# Altium schematic sheet Sheet1.SchDoc
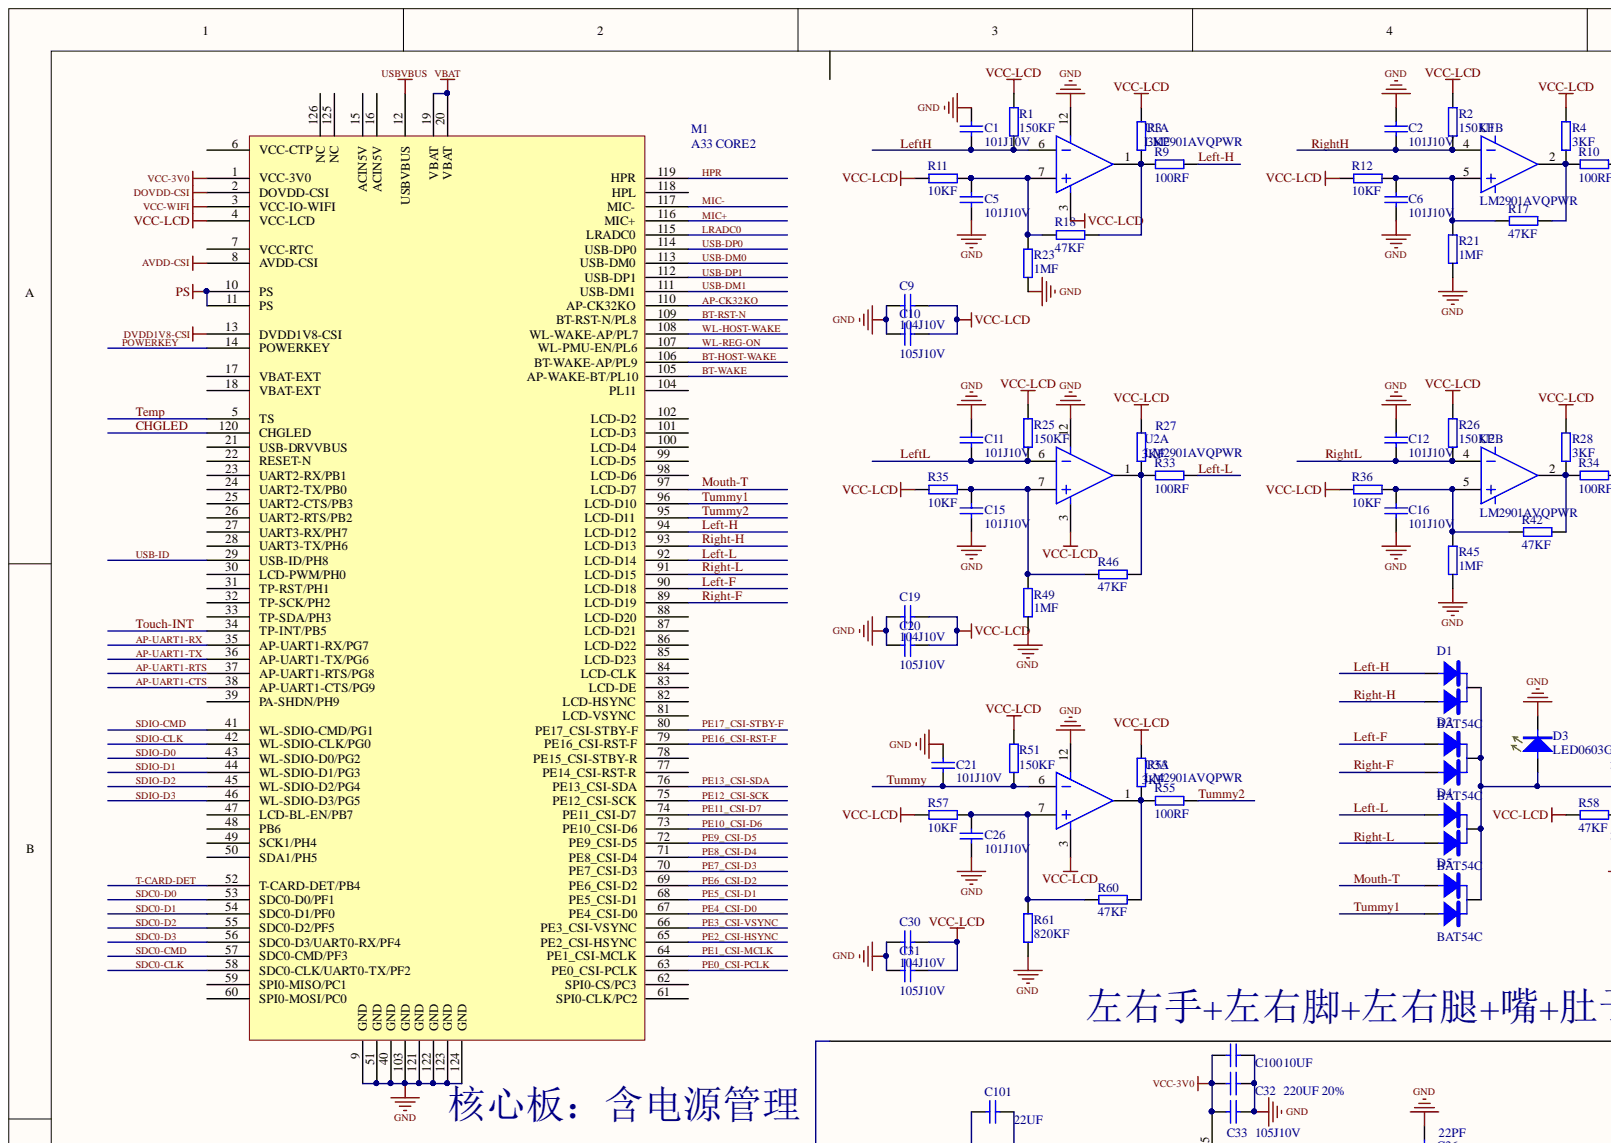
[diagram p0: Sheet1.SchDoc, top left]
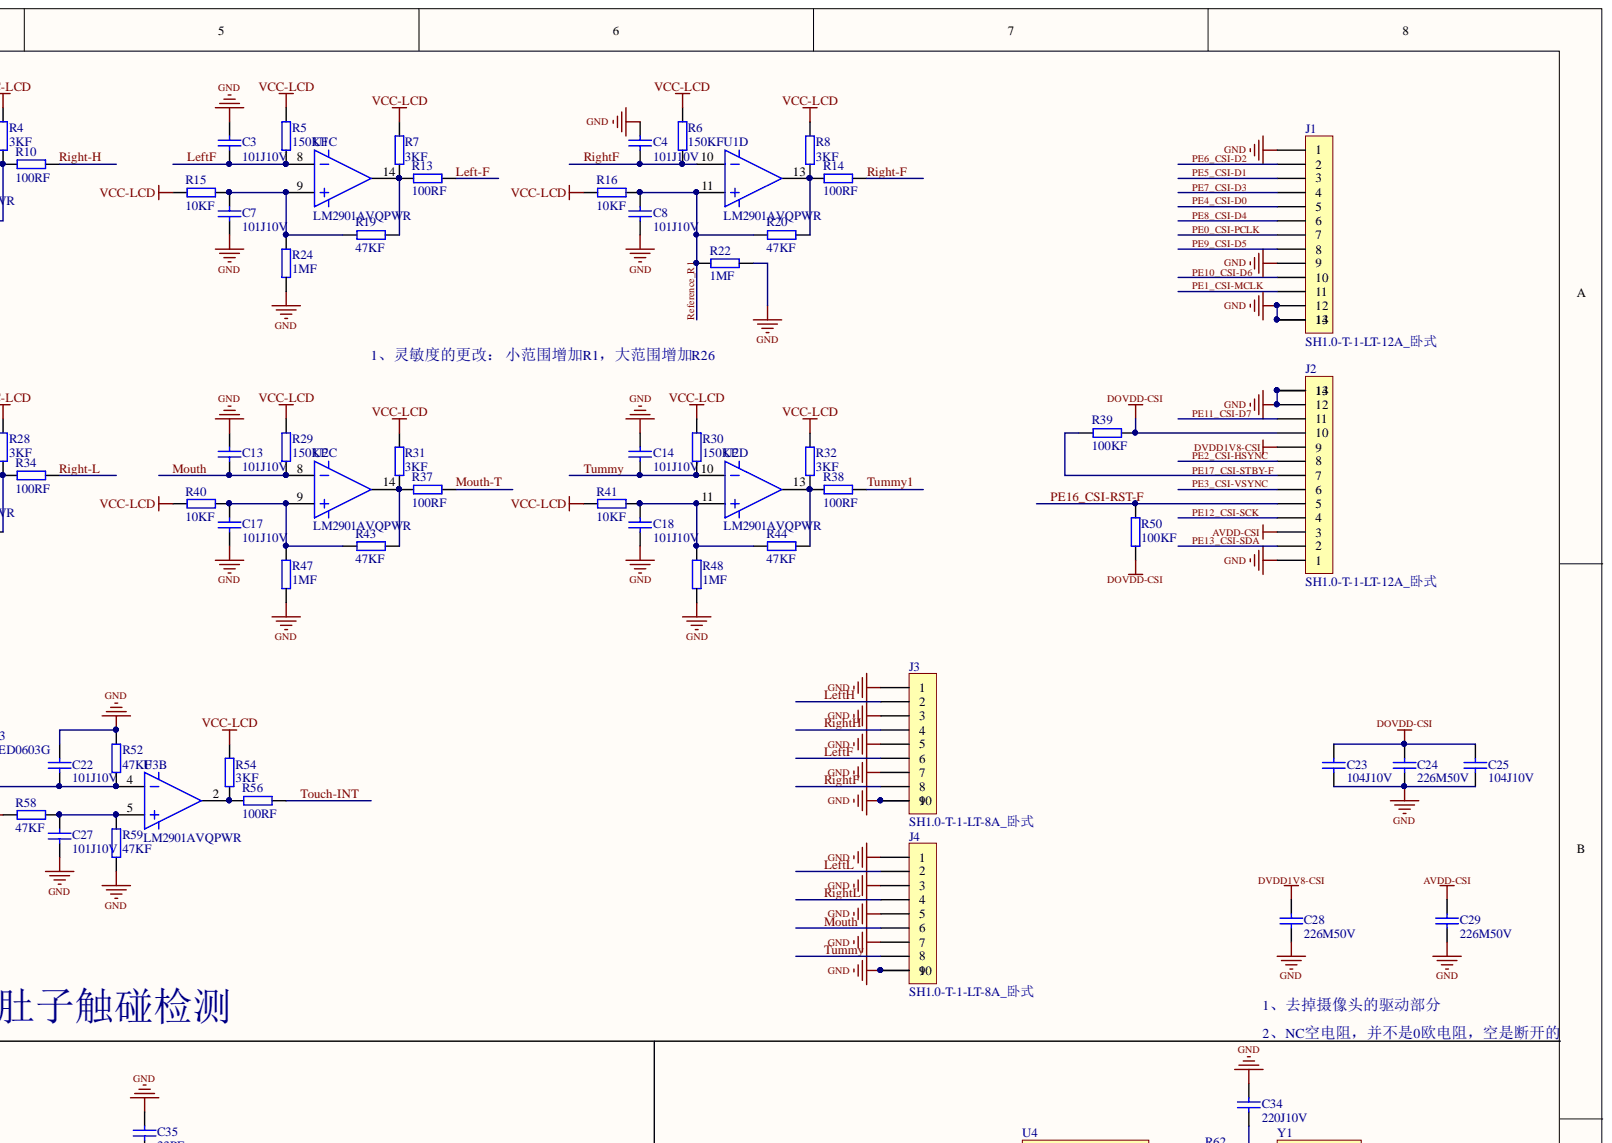
[diagram p1: Sheet1.SchDoc, top right]
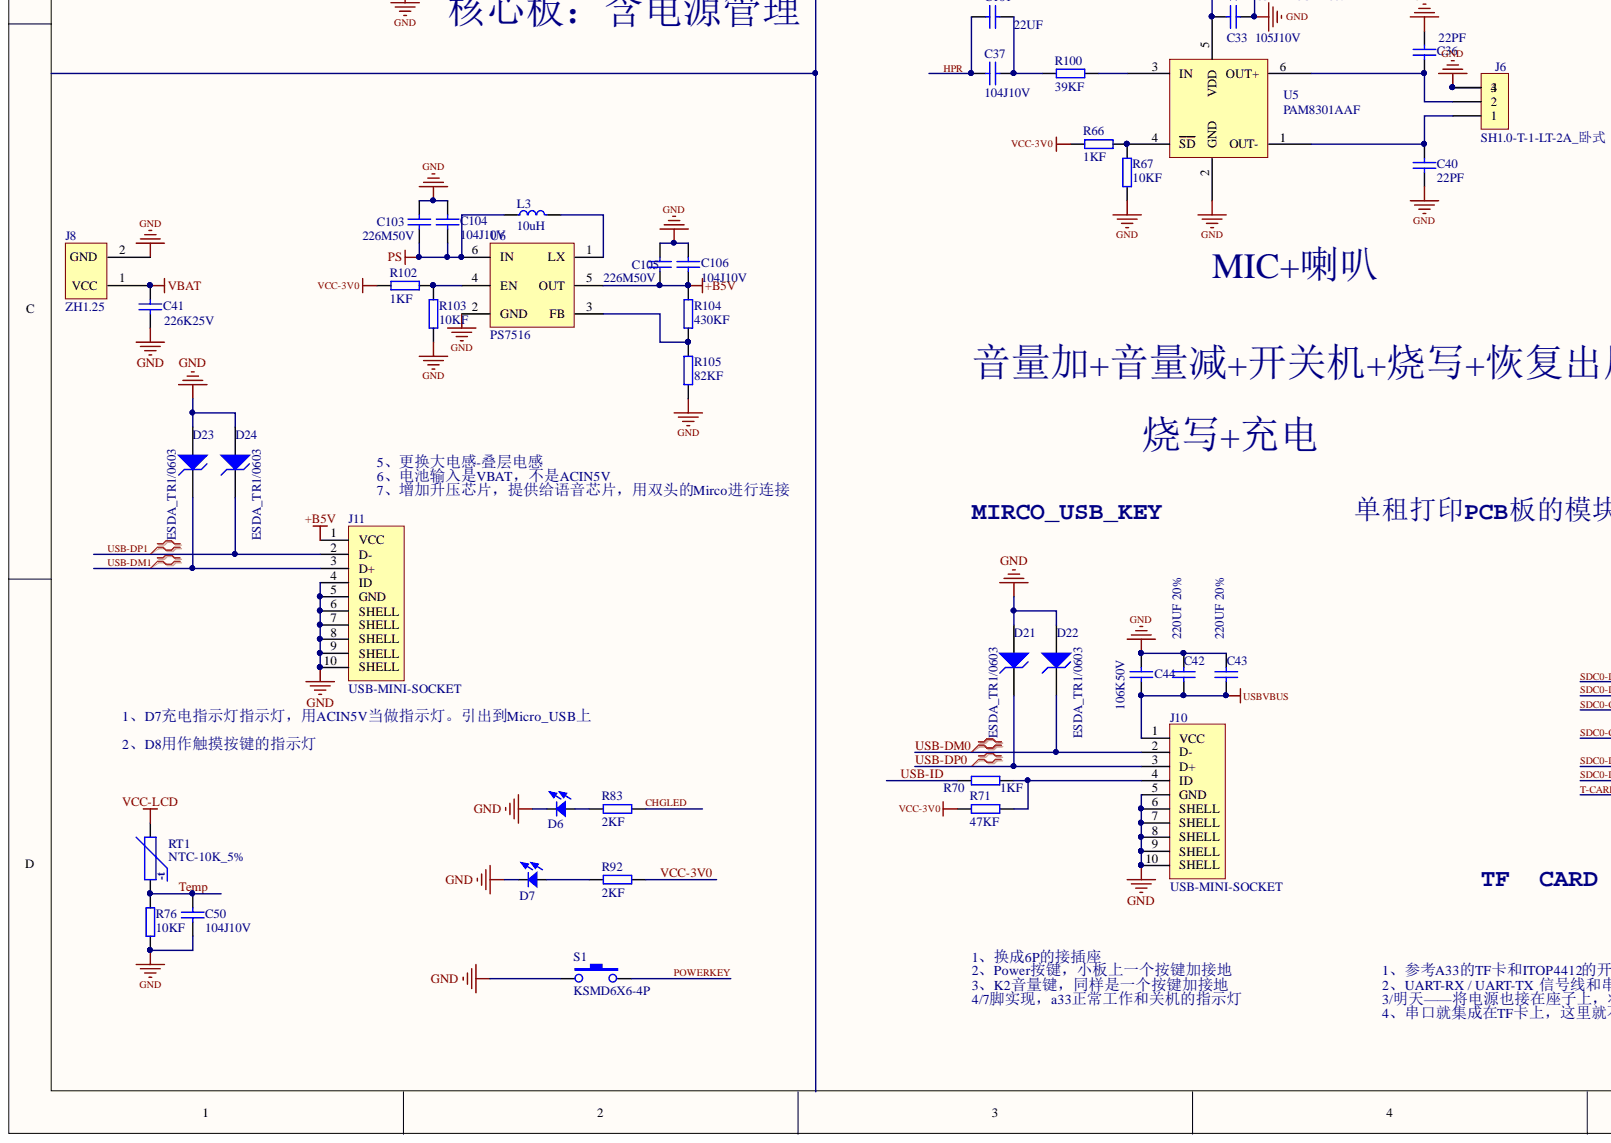
[diagram p2: Sheet1.SchDoc, bottom left]
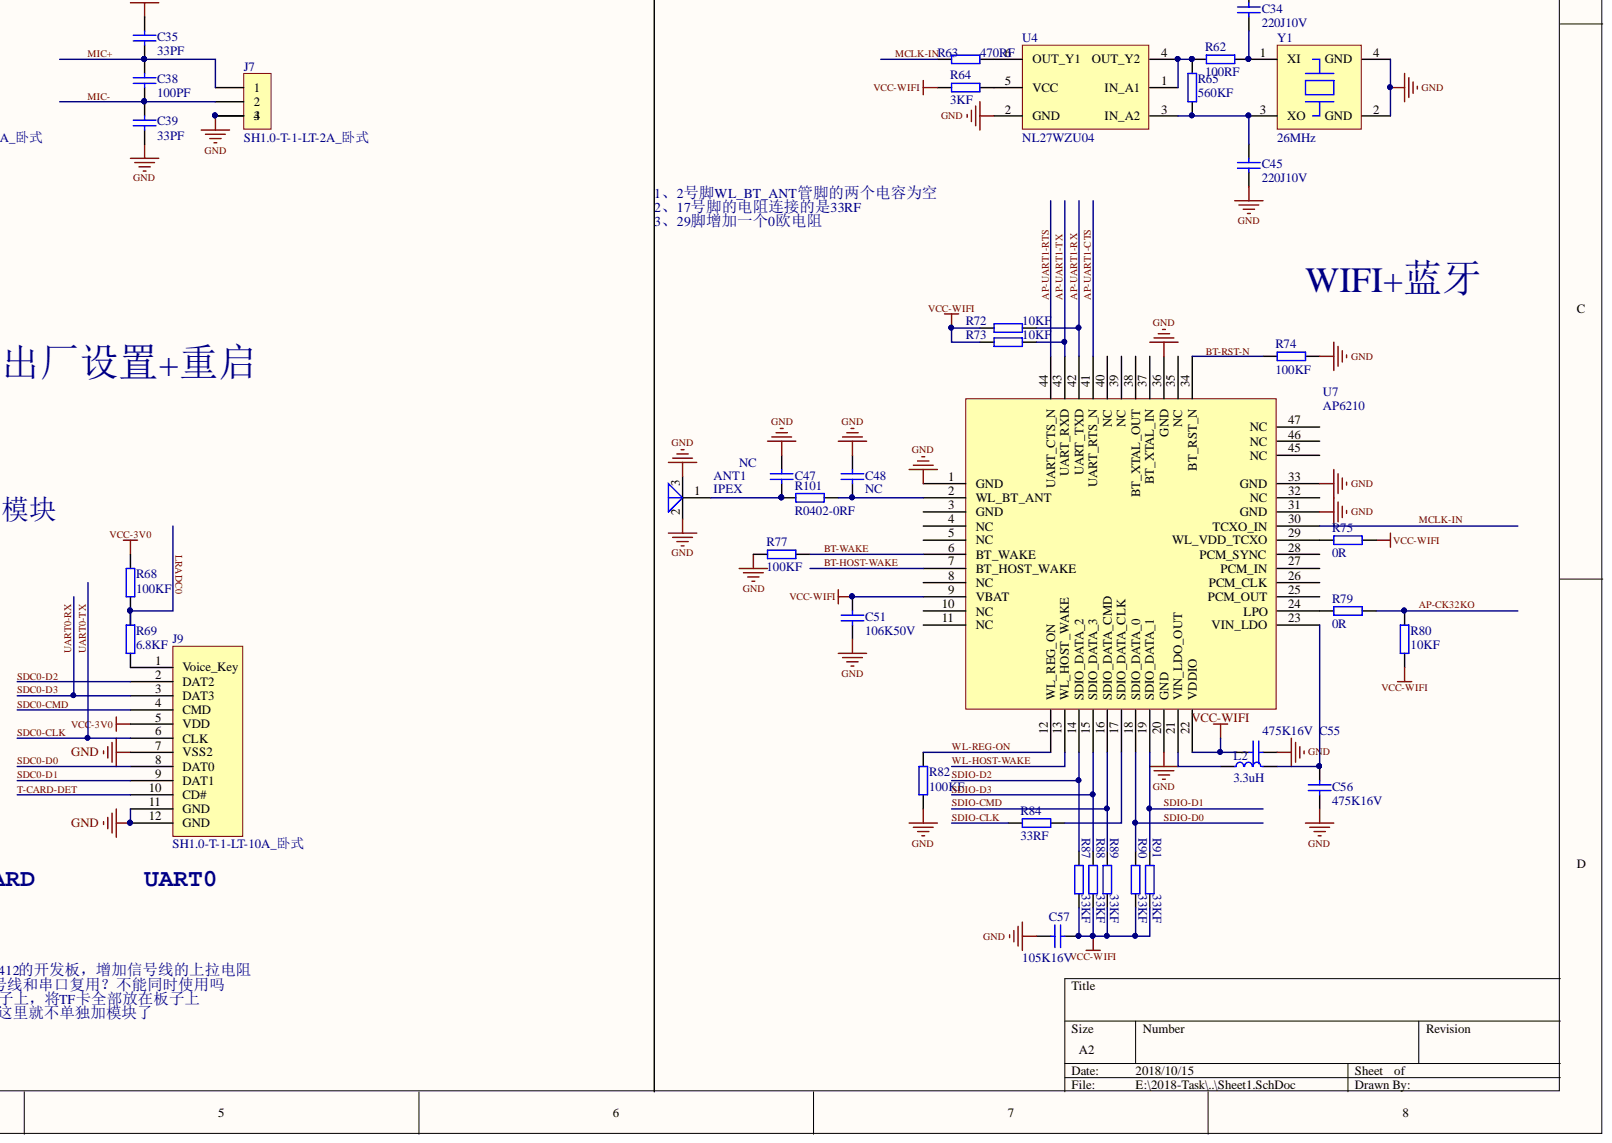
[diagram p3: Sheet1.SchDoc, bottom right]

## Parts
ANT1  IPEX  [J-IPEX]
C1  101J10V  [C0402]
C2  101J10V  [C0402]
C3  101J10V  [C0402]
C4  101J10V  [C0402]
C5  101J10V  [C0402]
C6  101J10V  [C0402]
C7  101J10V  [C0402]
C8  101J10V  [C0402]
C9  104J10V  [C0402]
C10  105J10V  [C0402]
C11  101J10V  [C0402]
C12  101J10V  [C0402]
C13  101J10V  [C0402]
C14  101J10V  [C0402]
C15  101J10V  [C0402]
C16  101J10V  [C0402]
C17  101J10V  [C0402]
C18  101J10V  [C0402]
C19  104J10V  [C0402]
C20  105J10V  [C0402]
C21  101J10V  [C0402]
C22  101J10V  [C0402]
C23  104J10V  [C0402]
C24  226M50V  [C0805]
C25  104J10V  [C0402]
C26  101J10V  [C0402]
C27  101J10V  [C0402]
C28  226M50V  [C0805]
C29  226M50V  [C0805]
C30  104J10V  [C0402]
C31  105J10V  [C0402]
C32  220UF 20%  [C1206]
C33  105J10V  [C0402]
C34  220J10V  [C0402]
C35  33PF  [C0402]
C36  22PF  [C0402]
C37  104J10V  [C0402]
C38  100PF  [C0402]
C39  33PF  [C0402]
C40  22PF  [C0402]
C41  226K25V  [C0805]
C42  220UF 20%  [C1206]
C43  220UF 20%  [C1206]
C44  106K50V  [C0603]
C45  220J10V  [C0402]
C47  NC  [C0402]
C48  NC  [C0402]
C50  104J10V  [C0402]
C51  106K50V  [C0603]
C55  475K16V  [C0603]
C56  475K16V  [C0603]
C57  105K16V  [C0603]
C100  10UF  [C0603]
C101  22UF  [C0805]
C103  226M50V  [C0805]
C104  104J10V  [C0402]
C105  226M50V  [C0805]
C106  104J10V  [C0402]
D1  BAT54C  [U-SOT-23-3]
D2  BAT54C  [U-SOT-23-3]
D3  LED0603G  [D-LED0603G]
D4  BAT54C  [U-SOT-23-3]
D5  BAT54C  [U-SOT-23-3]
D6  发光二极管  [LEDΦ5-H12]  (value not drawn)
D7  发光二极管  [LEDΦ5-H12]  (value not drawn)
D21  ESDA_TR1/0603  [HS_0603D]
D22  ESDA_TR1/0603  [HS_0603D]
D23  ESDA_TR1/0603  [HS_0603D]
D24  ESDA_TR1/0603  [HS_0603D]
J1  SH1.0-T-1-LT-12A_卧式  [J-SH1.0-T-1-LT-12A_卧式]
J2  SH1.0-T-1-LT-12A_卧式  [J-SH1.0-T-1-LT-12A_卧式]
J3  SH1.0-T-1-LT-8A_卧式  [J-SH1.0-T-1-LT-8A_卧式]
J4  SH1.0-T-1-LT-8A_卧式  [J-SH1.0-T-1-LT-8A_卧式]
J6  SH1.0-T-1-LT-2A_卧式  [J-SH1.0-T-1-LT-2A_卧式]
J7  SH1.0-T-1-LT-2A_卧式  [J-SH1.0-T-1-LT-2A_卧式]
J8  ZH1.25  [S1.25-2P]
J9  SH1.0-T-1-LT-10A_卧式  [J-SH1.0-T-1-LT-10A_卧式]
J10  USB-MINI-SOCKET  [MICRO-USB - 5.9]
J11  USB-MINI-SOCKET  [MICRO-USB - 5.9]
L2  3.3uH  [L0805]
L3  10uH  [L0805]
M1  A33 CORE2  [A33-CORE2]
R1  150KF  [R0603]
R2  150KF  [R0603]
R3  3KF  [R0603]
R4  3KF  [R0603]
R5  150KF  [R0603]
R6  150KF  [R0603]
R7  3KF  [R0603]
R8  3KF  [R0603]
R9  100RF  [R0603]
R10  100RF  [R0603]
R11  10KF  [R0603]
R12  10KF  [R0603]
R13  100RF  [R0603]
R14  100RF  [R0603]
R15  10KF  [R0603]
R16  10KF  [R0603]
R17  47KF  [R0603]
R18  47KF  [R0603]
R19  47KF  [R0603]
R20  47KF  [R0603]
R21  1MF  [R0603]
R22  1MF  [R0603]
R23  1MF  [R0603]
R24  1MF  [R0603]
R25  150KF  [R0603]
R26  150KF  [R0603]
R27  3KF  [R0603]
R28  3KF  [R0603]
R29  150KF  [R0603]
R30  150KF  [R0603]
R31  3KF  [R0603]
R32  3KF  [R0603]
R33  100RF  [R0603]
R34  100RF  [R0603]
R35  10KF  [R0603]
R36  10KF  [R0603]
R37  100RF  [R0603]
R38  100RF  [R0603]
R39  100KF  [R0603]
R40  10KF  [R0603]
R41  10KF  [R0603]
R42  47KF  [R0603]
R43  47KF  [R0603]
R44  47KF  [R0603]
R45  1MF  [R0603]
R46  47KF  [R0603]
R47  1MF  [R0603]
R48  1MF  [R0603]
R49  1MF  [R0603]
R50  100KF  [R0603]
R51  150KF  [R0603]
R52  47KF  [R0603]
R53  3KF  [R0603]
R54  3KF  [R0603]
R55  100RF  [R0603]
R56  100RF  [R0603]
R57  10KF  [R0603]
R58  47KF  [R0603]
R59  47KF  [R0603]
R60  47KF  [R0603]
R61  820KF  [R0603]
R62  100RF  [R0603]
R63  470RF  [R0603]
R64  3KF  [R0603]
R65  560KF  [R0603]
R66  1KF  [R0603]
R67  10KF  [R0603]
R68  100KF  [R0603]
R69  6.8KF  [R0603]
R70  1KF  [R0603]
R71  47KF  [R0603]
R72  10KF  [R0603]
R73  10KF  [R0603]
R74  100KF  [R0603]
R75  0R  [R0603]
R76  10KF  [R0603]
R77  100KF  [R0603]
R79  0R  [R0603]
R80  10KF  [R0603]
R82  100KF  [R0603]
R83  2KF  [R0603]
R84  33RF  [R0603]
R87  33KF  [R0603]
R88  33KF  [R0603]
R89  33KF  [R0603]
R90  33KF  [R0603]
R91  33KF  [R0603]
R92  2KF  [R0603]
R100  39KF  [R0603]
R101  R0402-0RF  [C0402]
R102  1KF  [R0603]
R103  10KF  [R0603]
R104  430KF  [R0603]
R105  82KF  [R0603]
RT1  NTC-10K_5%  [R-NTC-10K]
S1  KSMD6X6-4P  [SW2-6*6H]
U1  LM2901AVQPWR  [U-TSSOP14]  (parts A,B,C,D)
U2  LM2901AVQPWR  [U-TSSOP14]  (parts A,B,C,D)
U3  LM2901AVQPWR  [U-TSSOP14]  (parts A,B)
U4  NL27WZU04  [U-TSOP-6]  (value not drawn)
U5  PAM8301AAF  [U-TSOT26]
U6  PS7516  [U-SOT-23-6]
U7  AP6210  [AP6210]
Y1  26MHz  [Y-CPX-32GA-4P]

## Nets
+B5V: C105.1 C106.2 J11.1(VCC) R104.2 U6.5(OUT)
AVDD-CSI: C29.1 J2.3 M1.8(AVDD-CSI)
DOVDD-CSI: C23.1 C24.1 C25.1 J2.10 M1.2(DOVDD-CSI) R39.2 R50.1
DVDD1V8-CSI: C28.1 J2.9 M1.13(DVDD1V8-CSI)
GND: ANT1.2 ANT1.3 C1.1 C2.1 C3.1 C4.1 C5.2 C6.2 C7.2 C8.2 C9.1 C10.2 C11.1 C12.1 C13.1 C14.1 C15.2 C16.2 C17.2 C18.2 C19.1 C20.2 C21.1 C22.1 C23.2 C24.2 C25.2 C26.2 C27.2 C28.2 C29.2 C30.1 C31.2 C32.1 C33.1 C34.2 C35.1 C36.2 C39.2 C40.2 C41.2 C42.2 C43.2 C44.1 C45.2 C47.1 C48.1 C50.2 C51.2 C55.1 C56.1 C57.2 C100.2 C103.2 C104.1 C105.2 C106.1 D3.2 D6.K D7.K D21.1 D22.1 D23.1 D24.1 J1.1 J1.9 J1.12 J1.13 J1.14 J2.1 J2.12 J2.13 J2.14 J3.1 J3.3 J3.5 J3.7 J3.9 J3.10 J4.1 J4.3 J4.5 J4.7 J4.9 J4.10 J6.3 J6.4 J7.3 J7.4 J8.2 J9.7(VSS2) J9.11(GND) J9.12(GND) J10.5(GND) J10.6(SHELL) J10.7(SHELL) J10.8(SHELL) J10.9(SHELL) J10.10(SHELL) J11.4(ID) J11.5(GND) J11.6(SHELL) J11.7(SHELL) J11.8(SHELL) J11.9(SHELL) J11.10(SHELL) M1.9(GND) M1.40(GND) M1.51(GND) M1.103(GND) M1.121(GND) M1.122(GND) M1.123(GND) M1.124(GND) R21.1 R22.1 R23.1 R24.1 R45.1 R47.1 R48.1 R49.1 R52.1 R59.1 R61.1 R67.1 R74.2 R76.1 R77.2 R82.2 R103.1 R105.1 S1.1 U1.12 U2.12 U3.12 U4.2(GND) U5.2(GND) U6.2(GND) U7.1(GND) U7.20(GND) U7.31(GND) U7.33(GND) U7.36(GND) Y1.2(GND) Y1.4(GND)
PS: C103.1 C104.2 L3.1 M1.10(PS) M1.11(PS) U6.6(IN)
USBVBUS: C42.1 C43.1 C44.2 J10.1(VCC) M1.12(USBVBUS)
VBAT: C41.1 J8.1 M1.19(VBAT) M1.20(VBAT)
VCC-LCD: C9.2 C10.1 C19.2 C20.1 C30.2 C31.1 M1.4(VCC-LCD) R1.2 R2.2 R3.2 R4.2 R5.2 R6.2 R7.2 R8.2 R11.1 R12.1 R15.1 R16.1 R25.2 R26.2 R27.2 R28.2 R29.2 R30.2 R31.2 R32.2 R35.1 R36.1 R40.1 R41.1 R51.2 R53.2 R54.2 R57.1 R58.2 RT1.2 U1.3 U2.3 U3.3
VCC-WIFI: C51.1 C55.2 C57.1 M1.3(VCC-IO-WIFI) R64.1 R72.1 R73.1 R75.2 R80.1 R87.1 R88.1 R89.1 R90.1 R91.1 U7.9(VBAT) U7.22(VDDIO)
AP-CK32KO: M1.110(AP-CK32KO) R79.2 R80.2
AP-UART1-CTS: M1.38(AP-UART1-CTS/PG9) U7.41(UART_RTS_N)
AP-UART1-RTS: M1.37(AP-UART1-RTS/PG8) U7.44(UART_CTS_N)
AP-UART1-RX: M1.35(AP-UART1-RX/PG7) R72.2 U7.42(UART_TXD)
AP-UART1-TX: M1.36(AP-UART1-TX/PG6) R73.2 U7.43(UART_RXD)
BT-HOST-WAKE: M1.106(BT-WAKE-AP/PL9) U7.7(BT_HOST_WAKE)
BT-RST-N: M1.109(BT-RST-N/PL8) R74.1 U7.34(BT_RST_N)
BT-WAKE: M1.105(AP-WAKE-BT/PL10) R77.1 U7.6(BT_WAKE)
CHGLED: M1.120(CHGLED) R83.2
HPR: C37.2 C101.1 M1.119(HPR)
LEFT-F: D2.1 M1.90(LCD-D18) R13.2
LEFT-H: D1.2 M1.94(LCD-D12) R9.2
LEFT-L: D4.2 M1.92(LCD-D14) R33.2
LEFTF: C3.2 J3.6 R5.1 U1.8
LEFTH: C1.2 J3.2 R1.1 U1.6
LEFTL: C11.2 J4.2 R25.1 U2.6
LRADC0: M1.115(LRADC0) R68.2 R69.1
MCLK-IN: R63.1 U7.30(TCXO_IN)
MIC+: C35.2 C38.1 J7.1 M1.116(MIC+)
MIC-: C38.2 C39.1 J7.2 M1.117(MIC-)
MOUTH: C13.2 J4.6 R29.1 U2.8
MOUTH-T: D5.1 M1.97(LCD-D7) R37.2
PE0_CSI-PCLK: J1.7 M1.63(PE0_CSI-PCLK)
PE1_CSI-MCLK: J1.11 M1.64(PE1_CSI-MCLK)
PE2_CSI-HSYNC: J2.8 M1.65(PE2_CSI-HSYNC)
PE3_CSI-VSYNC: J2.6 M1.66(PE3_CSI-VSYNC)
PE4_CSI-D0: J1.5 M1.67(PE4_CSI-D0)
PE5_CSI-D1: J1.3 M1.68(PE5_CSI-D1)
PE6_CSI-D2: J1.2 M1.69(PE6_CSI-D2)
PE7_CSI-D3: J1.4 M1.70(PE7_CSI-D3)
PE8_CSI-D4: J1.6 M1.71(PE8_CSI-D4)
PE9_CSI-D5: J1.8 M1.72(PE9_CSI-D5)
PE10_CSI-D6: J1.10 M1.73(PE10_CSI-D6)
PE11_CSI-D7: J2.11 M1.74(PE11_CSI-D7)
PE12_CSI-SCK: J2.4 M1.75(PE12_CSI-SCK)
PE13_CSI-SDA: J2.2 M1.76(PE13_CSI-SDA)
PE16_CSI-RST-F: J2.5 M1.79(PE16_CSI-RST-F) R50.2
PE17_CSI-STBY-F: J2.7 M1.80(PE17_CSI-STBY-F) R39.1
POWERKEY: M1.14(POWERKEY) S1.2
REFERENCE_R1: C8.1 R16.2 R20.2 R22.2 U1.11
RIGHT-F: D2.2 M1.89(LCD-D19) R14.2
RIGHT-H: D1.1 M1.93(LCD-D13) R10.2
RIGHT-L: D4.1 M1.91(LCD-D15) R34.2
RIGHTF: C4.2 J3.8 R6.1 U1.10
RIGHTH: C2.2 J3.4 R2.1 U1.4
RIGHTL: C12.2 J4.4 R26.1 U2.4
SDC0-CLK: J9.6(CLK) M1.58(SDC0-CLK/UART0-TX/PF2)
SDC0-CMD: J9.4(CMD) M1.57(SDC0-CMD/PF3)
SDC0-D0: J9.8(DAT0) M1.53(SDC0-D0/PF1)
SDC0-D1: J9.9(DAT1) M1.54(SDC0-D1/PF0)
SDC0-D2: J9.2(DAT2) M1.55(SDC0-D2/PF5)
SDC0-D3: J9.3(DAT3) M1.56(SDC0-D3/UART0-RX/PF4)
SDIO-CLK: M1.42(WL-SDIO-CLK/PG0) R84.2
SDIO-CMD: M1.41(WL-SDIO-CMD/PG1) R89.2 U7.16(SDIO_DATA_CMD)
SDIO-D0: M1.43(WL-SDIO-D0/PG2) R90.2 U7.18(SDIO_DATA_0)
SDIO-D1: M1.44(WL-SDIO-D1/PG3) R91.2 U7.19(SDIO_DATA_1)
SDIO-D2: M1.45(WL-SDIO-D2/PG4) R87.2 U7.14(SDIO_DATA_2)
SDIO-D3: M1.46(WL-SDIO-D3/PG5) R88.2 U7.15(SDIO_DATA_3)
T-CARD-DET: J9.10(CD#) M1.52(T-CARD-DET/PB4)
TEMP: C50.1 M1.5(TS) R76.2 RT1.1
TOUCH-INT: M1.34(TP-INT/PB5) R56.2
TUMMY: C14.2 C21.2 J4.8 R30.1 R51.1 U2.10 U3.6
TUMMY1: D5.2 M1.96(LCD-D10) R38.2
TUMMY2: M1.95(LCD-D11) R55.2
USB-DM0: D22.2 J10.2(D-) M1.113(USB-DM0)
USB-DM1: D23.2 J11.3(D+) M1.111(USB-DM1)
USB-DP0: D21.2 J10.3(D+) M1.114(USB-DP0)
USB-DP1: D24.2 J11.2(D-) M1.112(USB-DP1)
USB-ID: M1.29(USB-ID/PH8) R70.1
VCC-3V0: C32.2 C33.2 C100.1 J9.5(VDD) M1.1(VCC-3V0) R66.1 R68.1 R71.2 R92.2 R102.1 U5.5(VDD)
WL-HOST-WAKE: M1.108(WL-WAKE-AP/PL7) U7.13(WL_HOST_WAKE)
WL-REG-ON: M1.107(WL-PMU-EN/PL6) R82.1 U7.12(WL_REG_ON)
NetANT1_1: ANT1.1 C47.2 R101.1
NetC5_1: C5.1 R11.2 R18.2 R23.2 U1.7
NetC6_1: C6.1 R12.2 R17.2 R21.2 U1.5
NetC7_1: C7.1 R15.2 R19.2 R24.2 U1.9
NetC15_1: C15.1 R35.2 R46.2 R49.2 U2.7
NetC16_1: C16.1 R36.2 R42.2 R45.2 U2.5
NetC17_1: C17.1 R40.2 R43.2 R47.2 U2.9
NetC18_1: C18.1 R41.2 R44.2 R48.2 U2.11
NetC22_2: C22.2 D1.3 D2.3 D3.1 D4.3 D5.3 R52.2 U3.4
NetC26_1: C26.1 R57.2 R60.1 R61.2 U3.7
NetC27_1: C27.1 R58.1 R59.2 U3.5
NetC34_1: C34.1 R62.2 Y1.1(XI)
NetC36_1: C36.1 J6.2 U5.6(OUT+)
NetC37_1: C37.1 C101.2 R100.1
NetC40_1: C40.1 J6.1 U5.1(OUT-)
NetC45_1: C45.1 R65.1 U4.3(IN_A2) Y1.3(XO)
NetC48_2: C48.2 R101.2 U7.2(WL_BT_ANT)
NetC56_2: C56.2 L2.2 U7.23(VIN_LDO)
NetD6_A: D6.A R83.1
NetD7_A: D7.A R92.1
NetJ9_1: J9.1(Voice_Key) R69.2
NetJ10_4: J10.4(ID) R70.2 R71.1
NetL2_1: L2.1 U7.21(VIN_LDO_OUT)
NetL3_2: L3.2 U6.1(LX)
NetR3_1: R3.1 R9.1 R18.1 U1.1
NetR4_1: R4.1 R10.1 R17.1 U1.2
NetR7_1: R7.1 R13.1 R19.1 U1.14
NetR8_1: R8.1 R14.1 R20.1 U1.13
NetR27_1: R27.1 R33.1 R46.1 U2.1
NetR28_1: R28.1 R34.1 R42.1 U2.2
NetR31_1: R31.1 R37.1 R43.1 U2.14
NetR32_1: R32.1 R38.1 R44.1 U2.13
NetR53_1: R53.1 R55.1 R60.2 U3.1
NetR54_1: R54.1 R56.1 U3.2
NetR62_1: R62.1 R65.2 U4.1(IN_A1) U4.4(OUT_Y2)
NetR63_2: R63.2 U4.6(OUT_Y1)
NetR64_2: R64.2 U4.5(VCC)
NetR66_2: R66.2 R67.2 U5.4(SD)
NetR75_1: R75.1 U7.29(WL_VDD_TCXO)
NetR79_1: R79.1 U7.24(LPO)
NetR84_1: R84.1 U7.17(SDIO_DATA_CLK)
NetR100_2: R100.2 U5.3(IN)
NetR102_2: R102.2 R103.2 U6.4(EN)
NetR104_1: R104.1 R105.2 U6.3(FB)
N00028:

Not connected: M1 6(VCC-CTP) 7(VCC-RTC) 15(ACIN5V) 16(ACIN5V) 17(VBAT-EXT) 18(VBAT-EXT) 21(USB-DRVVBUS) 22(RESET-N) 23(UART2-RX/PB1) 24(UART2-TX/PB0) 25(UART2-CTS/PB3) 26(UART2-RTS/PB2) 27(UART3-RX/PH7) 28(UART3-TX/PH6) 30(LCD-PWM/PH0) 31(TP-RST/PH1) 32(TP-SCK/PH2) 33(TP-SDA/PH3) 39(PA-SHDN/PH9) 47(LCD-BL-EN/PB7) 48(PB6) 49(SCK1/PH4) 50(SDA1/PH5) 59(SPI0-MISO/PC1) 60(SPI0-MOSI/PC0) 61(SPI0-CLK/PC2) 62(SPI0-CS/PC3) 77(PE14_CSI-RST-R) 78(PE15_CSI-STBY-R) 81(LCD-VSYNC) 82(LCD-HSYNC) 83(LCD-DE) 84(LCD-CLK) 85(LCD-D23) 86(LCD-D22) 87(LCD-D21) 88(LCD-D20) 98(LCD-D6) 99(LCD-D5) 100(LCD-D4) 101(LCD-D3) 102(LCD-D2) 104(PL11) 118(HPL) 125(NC) 126(NC); U7 3(GND) 4(NC) 5(NC) 8(NC) 10(NC) 11(NC) 25(PCM_OUT) 26(PCM_CLK) 27(PCM_IN) 28(PCM_SYNC) 32(NC) 35(NC) 37(BT_XTAL_IN) 38(BT_XTAL_OUT) 39(NC) 40(NC) 45(NC) 46(NC) 47(NC)

## Library data of the parts
ANT1 = J-IPEX: 天线接口IPEX; Component Kind: Standard; Library Name: Connector.SchLib
C1-C8 C11-C18 C21 C22 C26 C27 = C0402X7R-101J10V: 贴片电容-陶瓷; 生产商: 风华 三星; 规格型号: 100pF±5%_10V_X7R_C0402; Component Kind: Standard; Library Name: Capacitor.SchLib
C9 C19 C23 C25 C30 C35 C37-C39 C50 C104 C106 = C0402X7R-104J10V: 贴片电容-陶瓷; 生产商: 风华 三星; 规格型号: 100nF±5%_10V_X7R_C0402; : 无编码 有封装; Component Kind: Standard; Library Name: Capacitor.SchLib
C10 C20 C31 C33 = C0402X7R-105J10V: 贴片电容-陶瓷; 生产商: 风华 三星; 规格型号: 1uF±J%_10V_X7R_C0402; : 无编码 有封装; Component Kind: Standard; Library Name: Capacitor.SchLib
C24 C28 C29 C101 C103 C105 = C0805Y5V-226M50V: 贴片电容-陶瓷; 生产商: 风华 三星; 规格型号: 22uF±20%_50V_Y5V_C0805; : 无封装无编码; Component Kind: Standard; Library Name: Capacitor.SchLib
C32 C42 C43 = C1206-A型 极性电容: C 1206钽电容 --220UF 10V 3216 A型 有极性电容 3.2mm X 1.6mm; 参数: C 1206钽电容 --220UF 20% 10V 3216 A型 有极性 白色为正 电容 3.2mm X 1.6mm; Component Kind: Standard; Library Name: Capacitor.SchLib
C34 C36 C40 C45 C47 C48 = C0402X7R-220J10V: 贴片电容-陶瓷; 生产商: 风华 三星; 规格型号: 22pF±5%_10V_X7R_C0402; : 无编码有封装; Component Kind: Standard; Library Name: Capacitor.SchLib
C41 = C0805X7R-226K25V: 贴片电容-陶瓷; 生产商: 风华 三星; 规格型号: 22uF±10%_25V_X7R_C0805; Component Kind: Standard; Library Name: Capacitor.SchLib
C44 C51 = C0603X7R-106K50V: 贴片电容-陶瓷; 生产商: 风华 三星; 规格型号: 10uF±10%_50V_X7R_C0603; : 无编码 有封装; Component Kind: Standard; Library Name: Capacitor.SchLib
C55 C56 = C0603X7R-475K16V: 贴片电容-陶瓷; 生产商: 风华 三星; 规格型号: 4.7uF±10%_16V_X7R_C0603; : 无编码有封装; Component Kind: Standard; Library Name: Capacitor.SchLib
C57 = C0603X7R-105K16V: 贴片电容-陶瓷; 生产商: 风华 三星; 规格型号: 1uF±10%_16V_X7R_C0603; : 无编码 有封装; Component Kind: Standard; Library Name: Capacitor.SchLib
C100 = C0603X7R-330J25V: 贴片电容-陶瓷; 生产商: 风华 三星; 规格型号: 33pF±5%_25V_X7R_C0603; : 无编码有封装; Component Kind: Standard; Library Name: Capacitor.SchLib
D1 D2 D4 D5 = BAT54C: 贴片二极管-肖特基; 规格型号: BAT54C-0.2A30V; Component Kind: Standard; Library Name: Diode.SchLib
D3 = DLED-0603-G: 贴片二极管-LED; 规格型号: 0603-GREEN; 货品编码: 无样品无编码; Component Kind: Standard; Library Name: Diode.SchLib
D21-D24 = D Zener: Zener Diode; Component Kind: Standard; Library Name: 1_1.SCHLIB
J3 J4 = SH1.0-T-1-LT-8A_卧式: SH1.0-T-1-LT-6A_卧式; Component Kind: Standard; Library Name: Connector.SchLib
J8 = ZH1.25_2P: Component Kind: Standard; Description: ZH1.25; Library Name: PCB_Project.SCHLIB
J10 J11 = J-USB-MINI-SOCKET: USB-A卧式插座; Component Kind: Standard; Library Name: Connector.SchLib
L2 = L-0805-3.3uH: 贴片电感-层叠; 规格型号: L0805-3.3uH; Component Kind: Standard; Library Name: magnetic.SchLib
L3 = L-0805-10uH: 贴片电感-层叠; 规格型号: L0805-10uH; 货品编码: 351002; Component Kind: Standard; Library Name: magnetic.SchLib
R1 R2 R5 R6 R25 R26 R29 R30 R51 = R0603-150KF: 贴片电阻-通用; 生产商: 厚声 国巨; 规格型号: 150K±1%_1/10W_±200ppm_R0603; 货品编码: 无样品无编码; Component Kind: Standard; Library Name: Resistor.SchLib
R3 R4 R7 R8 R27 R28 R31 R32 R53 R54 R64 R83 = R0603-3KF: 贴片电阻-通用; 生产商: 厚声 国巨; 规格型号: 3K±1%_1/10W_±200ppm_R0603; 货品编码: 无样品无编码; Component Kind: Standard; Library Name: Resistor.SchLib
R9 R10 R13 R14 R33 R34 R37 R38 R55 R56 R62 = R0603-100RF: 贴片电阻-通用; 生产商: 厚声 国巨; 规格型号: 100R±1%_1/10W_±200ppm_R0603; Component Kind: Standard; Library Name: Resistor.SchLib
R11 R12 R15 R16 R35 R36 R40 R41 R57 R59 R60 R67 R72 R73 R76 R80 R100 R103 = R0603-10KF: 贴片电阻-通用; 生产商: 厚声 国巨; 规格型号: 10K±1%_1/10W_±200ppm_R0603; Component Kind: Standard; Library Name: Resistor.SchLib
R17-R20 R42-R44 R46 R52 R58 R71 = R0603-47KF: 贴片电阻-通用; 生产商: 厚声 国巨; 规格型号: 47K±1%_1/10W_±200ppm_R0603; 货品编码: 无样品无编码; Component Kind: Standard; Library Name: Resistor.SchLib
R21-R24 R45 R47-R49 = R0603-1MF: 贴片电阻-通用; value: 1MF 0603贴片电阻-通用; Component Kind: Standard; Library Name: Resistor.SchLib
R39 R50 R68 R74 R77 R82 = R0603-100KF: 贴片电阻-通用; 生产商: 厚声 国巨; 规格型号: 100K±1%_1/10W_±200ppm_R0603; 货品编码: 无样品无编码; Component Kind: Standard; Library Name: Resistor.SchLib
R61 = R0603-820KF: 贴片电阻-通用; 生产商: 厚声 国巨; 规格型号: 820K±1%_1/10W_±200ppm_R0603; 货品编码: 无样品无编码; Component Kind: Standard; Library Name: Resistor.SchLib
R63 = R0603-470RF: 贴片电阻-通用; 生产商: 厚声 国巨; 规格型号: 470R±1%_1/10W_±200ppm_R0603; 货品编码: 无样品无编码; Component Kind: Standard; Library Name: Resistor.SchLib
R65 = R0603-560KF: 贴片电阻-通用; 生产商: 厚声 国巨; 规格型号: 560K±1%_1/10W_±200ppm_R0603; Component Kind: Standard; Library Name: Resistor.SchLib
R66 R70 R102 = R0603-1KF: 贴片电阻-通用; 生产商: 厚声 国巨; 规格型号: 1K±1%_1/10W_±200ppm_R0603; 货品编码: 无样品无编码; Component Kind: Standard; Library Name: Resistor.SchLib
R69 = R0603-6.8KF: 贴片电阻-通用; 生产商: 厚声 国巨; 规格型号: 6.8K±1%_1/10W_±200ppm_R0603; 货品编码: 无样品无编码; Component Kind: Standard; Library Name: Resistor.SchLib
R75 R79 = R0603-0R: 贴片电阻-通用; 生产商: 厚声 国巨; 规格型号: 0R_1/10W_±200ppm_R0603; 货品编码: 无样品无编码; Component Kind: Standard; Library Name: Resistor.SchLib
R84 = R0603-33RF: 贴片电阻-通用; 生产商: 厚声 国巨; 规格型号: 33R±1%_1/10W_±200ppm_R0603; 货品编码: 无样品无编码; Component Kind: Standard; Library Name: Resistor.SchLib
R87-R91 = R0603-33KF: 贴片电阻-通用; 生产商: 厚声 国巨; 规格型号: 33K±1%_1/10W_±200ppm_R0603; Component Kind: Standard; Library Name: Resistor.SchLib
R92 = R0603-2KF: 贴片电阻-通用; 生产商: 厚声 国巨; 规格型号: 2K±1%_1/10W_±200ppm_R0603; Component Kind: Standard; Library Name: Resistor.SchLib
R104 = R0603-430KF: 贴片电阻-通用; 生产商: 厚声 国巨; 规格型号: 430K±1%_1/10W_±200ppm_R0603; Component Kind: Standard; Library Name: Resistor.SchLib
R105 = R0603-82KF: 贴片电阻-通用; 生产商: 厚声 国巨; 规格型号: 82K±1%_1/10W_±200ppm_R0603; Component Kind: Standard; Library Name: Resistor.SchLib
RT1 = RNTC-10K_5%: 插件电阻-热敏; 规格型号: 10K±5%_MF52A_NTC-10K; 货品编码: 312077; Component Kind: Standard; Library Name: Resistor.SchLib
S1 = S-KSMD6X6-4P: 轻触按键; 规格型号: 6x6 SMD贴片按键; 货品编码: 232013; Component Kind: Standard; Library Name: Key.SchLib
Y1 = YC-26MHz: 晶振-贴片无源; 规格型号: 26MHz_贴片晶振3225; Component Kind: Standard; Library Name: Others.SchLib
D6 D7 = 发光二极管: Component Kind: Standard; Library Name: 1_1.SCHLIB
J1 J2 = SH1.0-T-1-LT-12A_卧式: Component Kind: Standard; Description: SH1.0-T-1-LT-12A_卧式; Library Name: Connector.SchLib
J6 J7 = SH1.0-T-1-LT-2A_卧式: Component Kind: Standard; Description: SH1.0-T-1-LT-2A_卧式; Library Name: Connector.SchLib
J9 = SH1.0-T-1-LT-10A_卧式: Component Kind: Standard; Description: SH1.0-T-1-LT-10A_卧式; Library Name: Connector.SchLib
M1 = A33 CORE2: Component Kind: Standard; Library Name: Module.SchLib
R101 = R0402-0RF: Component Kind: Standard; Description: R0402-0RF; Library Name: PCB_Project.SCHLIB
U1-U3 = LM2901AVQPWR: Component Kind: Standard; Description: LM2901AVQPWR; Library Name: IC.SchLib
U4 = NL27WZU04: Component Kind: Standard; Description: NL27WZU04; Library Name: IC.SchLib
U5 = PAM8301AAF: Component Kind: Standard; Description: PAM8301AAF; Library Name: IC.SchLib
U6 = PS7516: Component Kind: Standard; Description: PS7516; Library Name: IC.SchLib
U7 = AP6210: Component Kind: Standard; Library Name: IC.SchLib
